# Altium schematic sheet Art_Imp.SchDoc
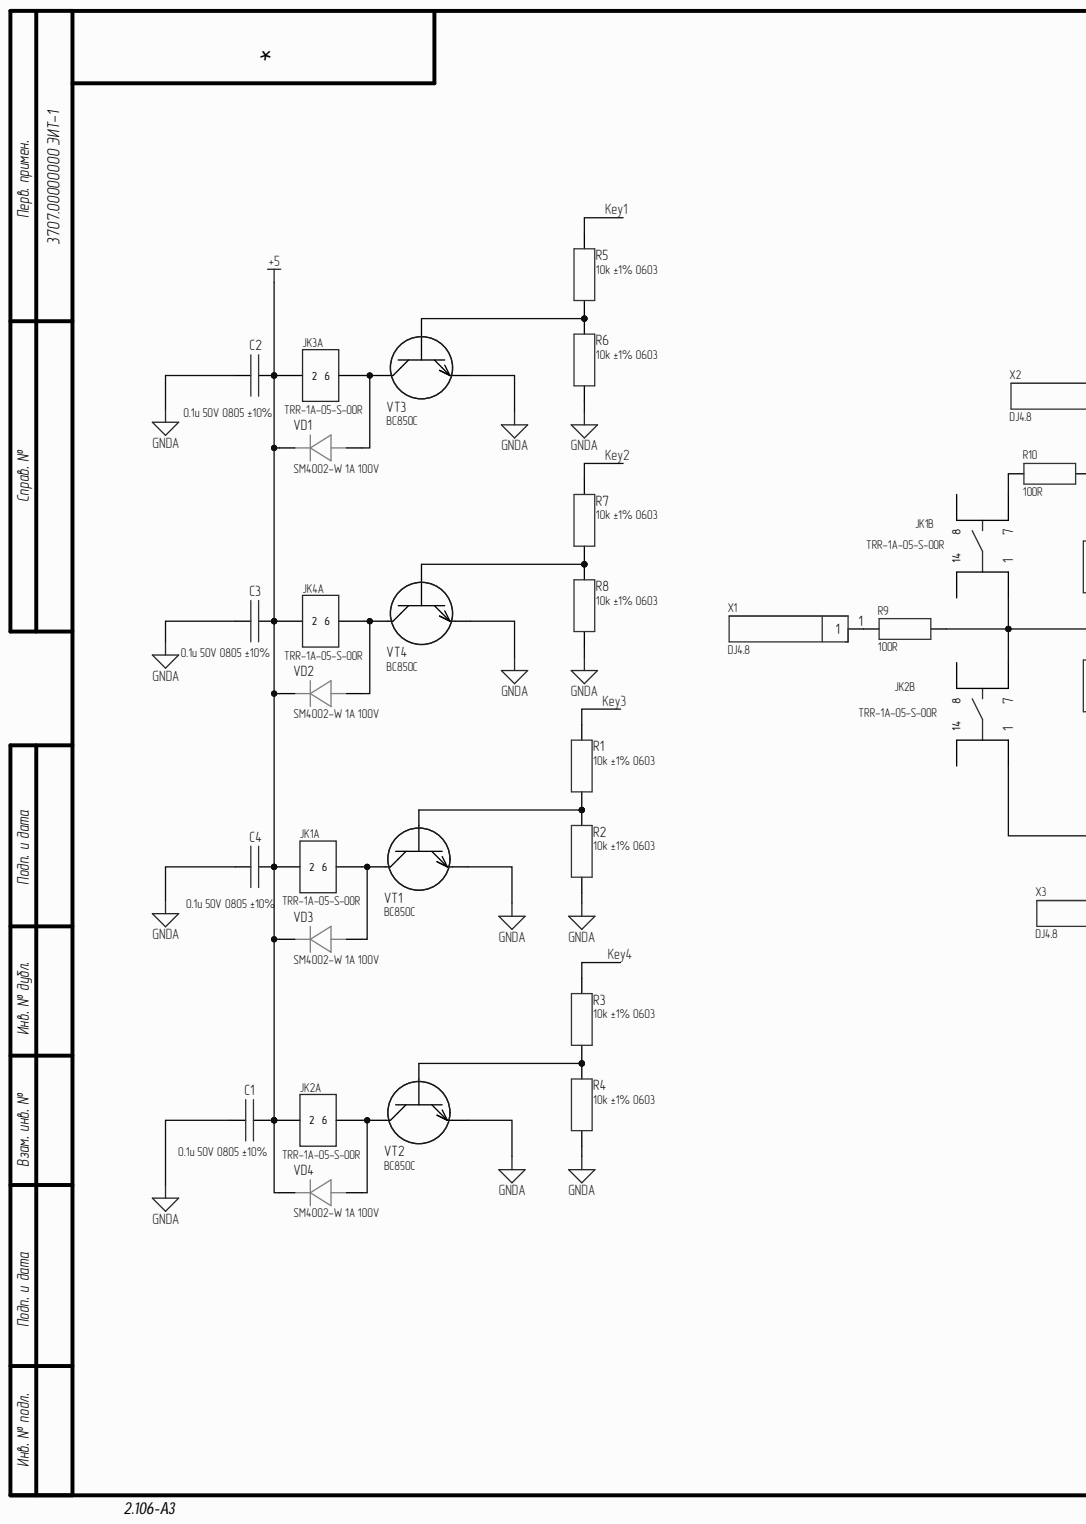
[diagram p0: Art_Imp.SchDoc, left]
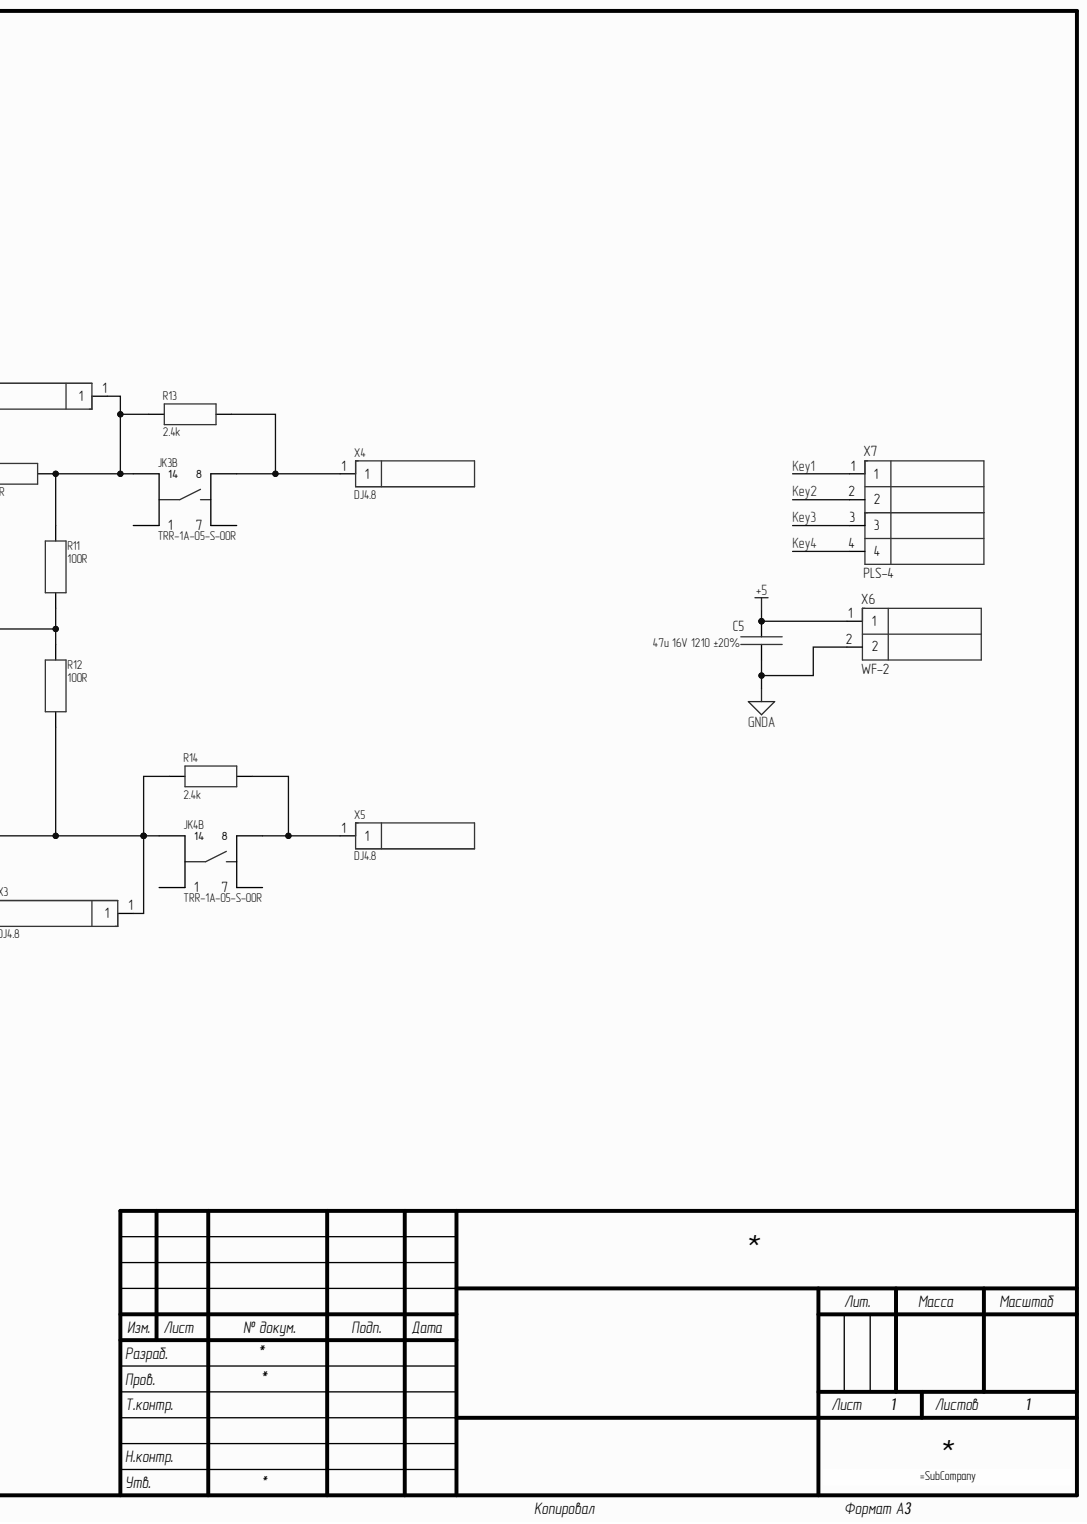
[diagram p1: Art_Imp.SchDoc, right]

## Parts
C1  0.1u 50V 0805 ±10%  [CAP: SMD 0805 MD IPC 782]
C2  0.1u 50V 0805 ±10%  [CAP: SMD 0805 MD IPC 782]
C3  0.1u 50V 0805 ±10%  [CAP: SMD 0805 MD IPC 782]
C4  0.1u 50V 0805 ±10%  [CAP: SMD 0805 MD IPC 782]
C5  47u 16V 1210 ±20%  [CAP: SMD 1210 MD IPC 782]
JK1  TRR-1A-05-S-00R  [TTR]  (parts A,B)
JK2  TRR-1A-05-S-00R  [TTR]  (parts A,B)
JK3  TRR-1A-05-S-00R  [TTR]  (parts A,B)
JK4  TRR-1A-05-S-00R  [TTR]  (parts A,B)
R1  10k ±1% 0603  [RES: SMD 0603 MD IPC 782]
R2  10k ±1% 0603  [RES: SMD 0603 MD IPC 782]
R3  10k ±1% 0603  [RES: SMD 0603 MD IPC 782]
R4  10k ±1% 0603  [RES: SMD 0603 MD IPC 782]
R5  10k ±1% 0603  [RES: SMD 0603 MD IPC 782]
R6  10k ±1% 0603  [RES: SMD 0603 MD IPC 782]
R7  10k ±1% 0603  [RES: SMD 0603 MD IPC 782]
R8  10k ±1% 0603  [RES: SMD 0603 MD IPC 782]
R9  100R  [0805]
R10  100R  [0805]
R11  100R  [0805]
R12  100R  [0805]
R13  2.4k  [0805]
R14  2.4k  [0805]
VD1  SM4002-W 1A 100V  [DIODE: MELF]
VD2  SM4002-W 1A 100V  [DIODE: MELF]
VD3  SM4002-W 1A 100V  [DIODE: MELF]
VD4  SM4002-W 1A 100V  [DIODE: MELF]
VT1  BC850C  [IC: SOT 23]
VT2  BC850C  [IC: SOT 23]
VT3  BC850C  [IC: SOT 23]
VT4  BC850C  [IC: SOT 23]
X1  DJ4.8  [DJ4.8]
X2  DJ4.8  [DJ4.8]
X3  DJ4.8  [DJ4.8]
X4  DJ4.8  [DJ4.8]
X5  DJ4.8  [DJ4.8]
X6  WF-2  [CON: 2pin wf-2]
X7  PLS-4  [CON: 4pin PLS-4]

## Nets
+5: C1.1 C2.2 C3.2 C4.2 C5.1 JK1.2 JK2.2 JK3.2 JK4.2 VD1.1 VD2.1 VD3.1 VD4.1 X6.1
GNDA: C1.2 C2.1 C3.1 C4.1 C5.2 R2.1 R4.1 R6.1 R8.1 VT1.2 VT2.2 VT3.2 VT4.2 X6.2
Key1: R5.2 X7.1
Key2: R7.2 X7.2
Key3: R1.2 X7.3
Key4: R3.2 X7.4
NetJK1_1: JK1.1 JK2.7 R9.2 R11.1 R12.2
NetJK1_6: JK1.6 VD3.2 VT1.3
NetJK1_7: JK1.7 R10.1
NetJK2_1: JK2.1 JK4.14 R12.1 R14.1 X3.1
NetJK2_6: JK2.6 VD4.2 VT2.3
NetJK3_6: JK3.6 VD1.2 VT3.3
NetJK3_8: JK3.8 R13.2 X4.1
NetJK3_14: JK3.14 R10.2 R11.2 R13.1 X2.1
NetJK4_6: JK4.6 VD2.2 VT4.3
NetJK4_8: JK4.8 R14.2 X5.1
NetR1_1: R1.1 R2.2 VT1.1
NetR3_1: R3.1 R4.2 VT2.1
NetR5_1: R5.1 R6.2 VT3.1
NetR7_1: R7.1 R8.2 VT4.1
NetR9_1: R9.1 X1.1

Not connected: JK1 8 14; JK2 8 14; JK3 1 7; JK4 1 7

## Library data of the parts
C1-C4 = 0.1u 50V 0805 ±10%: Code: 6279254871; Name: Конденсатор X7R 50V 0,1uF ±10% 0805; Component Kind: Standard; Library Name: UOMP_capacitors.SchLib
C5 = 47u 16V 1210 ±20%: Code: 6289734921; Name: Конденсатор GRM32ER61C476ME15L; Component Kind: Standard; Library Name: UOMP_capacitors.SchLib
JK1-JK4 = Реле герконовые TRR-1A-05-S-00R: P/N: Реле герконовые TRR-1A-05-S-00R, Tai Shing; Component Kind: Standard; ComponentLink1Description: Chipdip; ComponentLink1URL: https://www.chipdip.ru/product/trr-1a-05-s-00r-tai-shing-tti-8001786496; Description: Реле герконовые TRR-1A-05-S-00R; Library Name: Metal_Opera_Relay.SchLib
R1-R8 = 10k ±1% 0603: Code: 6069191961; Name: Резистор 10K+-1% TK50 0603; Component Kind: Standard; Library Name: UOMP_resistors.SchLib
R9-R12 = 100 0,05% 0805: 0805; P/N: Резистор RG2012N-101-W-T1, Susumu; Component Kind: Standard; ComponentLink1Description: Chipdip; ComponentLink1URL: https://www.chipdip.ru/product/rg1608n-131-w-t1-susumu-8001999350; Library Name: Metal_Opera_Resistors.SchLib
R13 R14 = 2.4k 0,05% 0805: 0805; P/N: Резистор RG2012N-242-W-T1 Susumu; Component Kind: Standard; ComponentLink1Description: Chipdip; ComponentLink1URL: https://www.chipdip.ru/product/rg2012n-242-w-t1-susumu-8001999371; Library Name: Metal_Opera_Resistors.SchLib
VD1-VD4 = Rectifier 1A 100V: Code: 6341199702; Name: Диод SM4002-W, RECTRON; Component Kind: Standard; Library Name: UOMP_diode.SchLib
VT1-VT4 = BJT NPN BC850C: Code: 6340001151; Name: Транзистор BC850C,215, Nexperia; Component Kind: Standard; Library Name: UOMP_transistors.SchLib
X1-X5 = 1 pin DJ4.8: Клемма ножевая 4.8 мм; Component Kind: Standard; Library Name: Metal_Opera_Connectors.SchLib
X6 = 2 pin WF-2: Code: 6313952902; Name: Вилка WF-2; Component Kind: Standard; Library Name: UOMP_connectors.SchLib
X7 = 4 pin PLS-4: Code: 6313932041; Name: Вилка WF-4; Component Kind: Standard; Library Name: UOMP_connectors.SchLib
